# Altium schematic sheet Comparators.SchDoc(Comparators)
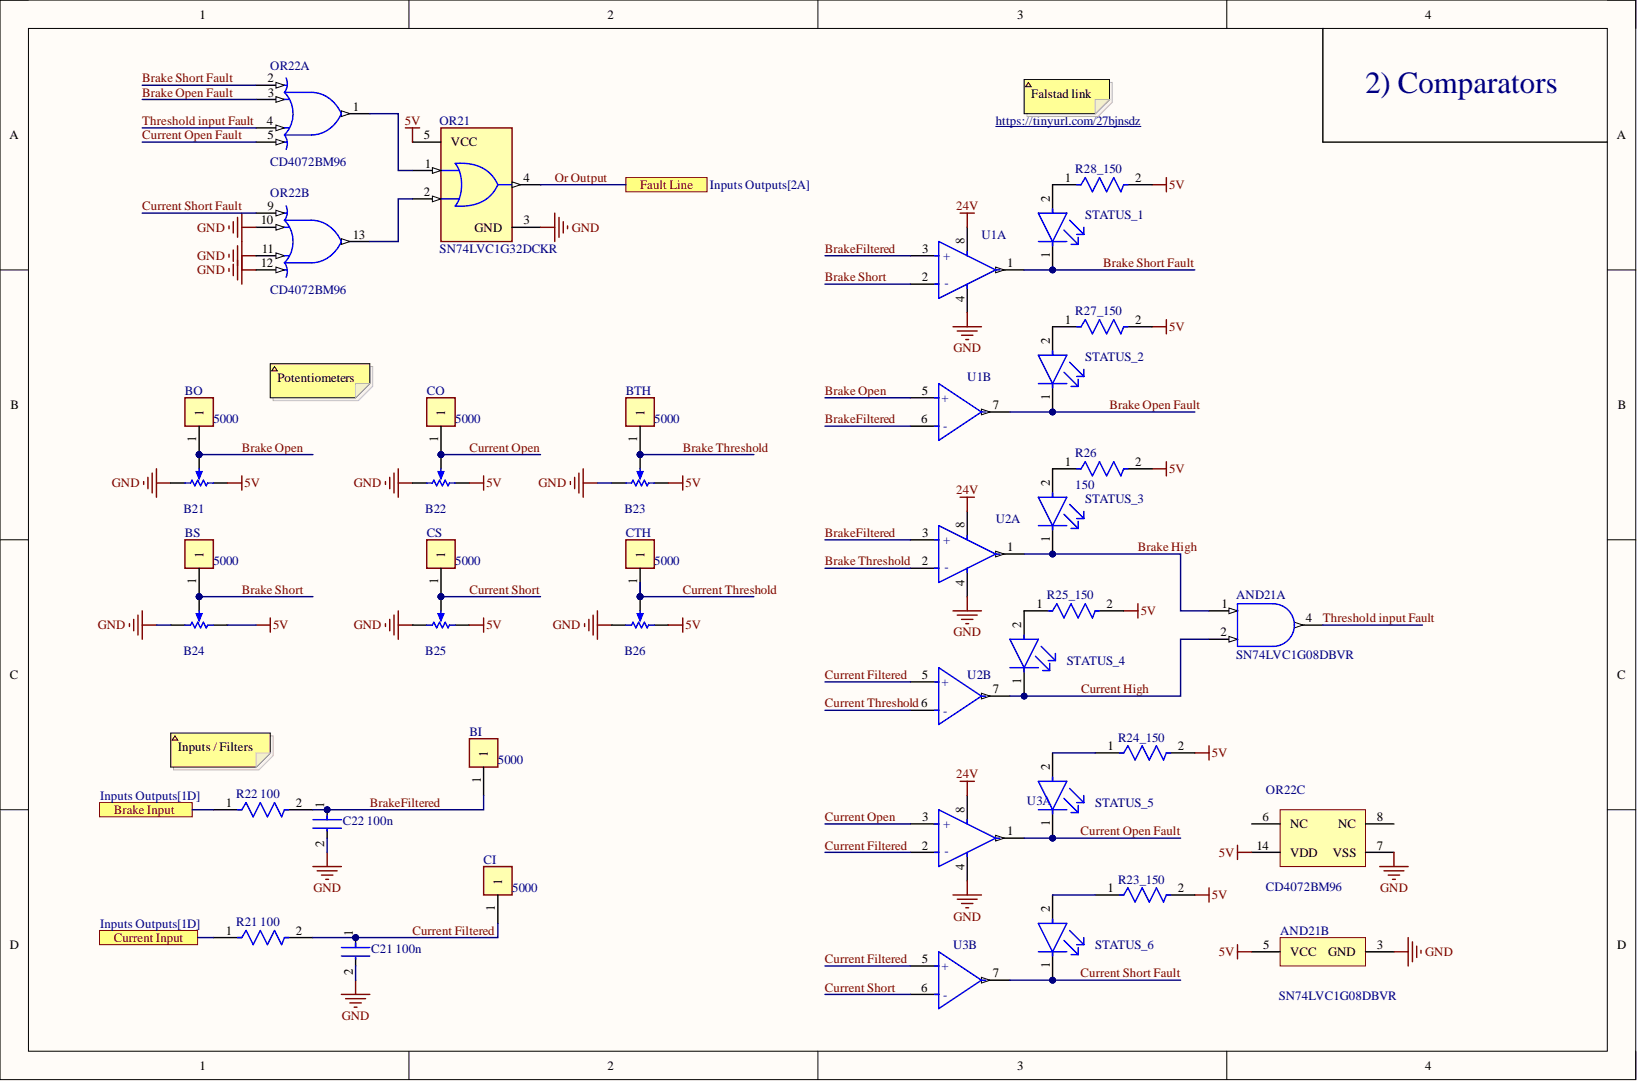
[diagram p0: Comparators.SchDoc]

## Parts
AND21  SN74LVC1G08DBVR  (parts A,B)
B21  3362P-1-103LF  (value not drawn)
B22  3362P-1-103LF  (value not drawn)
B23  3362P-1-103LF  (value not drawn)
B24  3362P-1-103LF  (value not drawn)
B25  3362P-1-103LF  (value not drawn)
B26  3362P-1-103LF  (value not drawn)
BI  5000
BO  5000
BS  5000
BTH  5000
C21_100n  12061C104KAZ2A  (value not drawn)
C22_100n  12061C104KAZ2A  (value not drawn)
CI  5000
CO  5000
CS  5000
CTH  5000
OR21  SN74LVC1G32DCKR
OR22  CD4072BM96  (parts A,B,C)
R21_100  RG2012P-101-B-T5  (value not drawn)
R22_100  RG2012P-101-B-T5  (value not drawn)
R23_150  LTR18EZPF1500  (value not drawn)
R24_150  LTR18EZPF1500  (value not drawn)
R25_150  LTR18EZPF1500  (value not drawn)
R26  150
R27_150  LTR18EZPF1500  (value not drawn)
R28_150  LTR18EZPF1500  (value not drawn)
STATUS_1  LTST-C190KRKT  (value not drawn)
STATUS_2  LTST-C190KRKT  (value not drawn)
STATUS_3  LTST-C190KRKT  (value not drawn)
STATUS_4  LTST-C190KRKT  (value not drawn)
STATUS_5  LTST-C190KRKT  (value not drawn)
STATUS_6  LTST-C190KRKT  (value not drawn)
U1  LM2903DGKR  (value not drawn)  (parts A,B)
U2  LM2903DGKR  (value not drawn)  (parts A,B)
U3  LM2903DGKR  (value not drawn)  (parts A,B)

## Ports
Brake Input, Current Input, Fault Line

## Nets
5V: AND21.5(VCC) B21.1 B22.1 B23.1 B24.1 B25.1 B26.1 OR21.5(VCC) OR22.14(VDD) R23_150.2 R24_150.2 R25_150.2 R26.2 R27_150.2 R28_150.2
24V: U1.8 U2.8 U3.8
GND: AND21.3(GND) B21.3 B22.3 B23.3 B24.3 B25.3 B26.3 C21_100n.2 C22_100n.2 OR21.3(GND) OR22.7(VSS) OR22.10 OR22.11 OR22.12 U1.4 U2.4 U3.4
Brake High: AND21.1 STATUS_3.1 U2.1
Brake Input: R22_100.1 ; port Brake Input
Brake Open: B21.2 BO.1 U1.5(+)
Brake Open Fault: OR22.3 STATUS_2.1 U1.7
Brake Short: B24.2 BS.1 U1.2(-)
Brake Short Fault: OR22.2 STATUS_1.1 U1.1
Brake Threshold: B23.2 BTH.1 U2.2(-)
BrakeFiltered: BI.1 C22_100n.1 R22_100.2 U1.3(+) U1.6(-) U2.3(+)
Current Filtered: C21_100n.1 CI.1 R21_100.2 U2.5(+) U3.2(-) U3.5(+)
Current High: AND21.2 STATUS_4.1 U2.7
Current Input: R21_100.1 ; port Current Input
Current Open: B22.2 CO.1 U3.3(+)
Current Open Fault: OR22.5 STATUS_5.1 U3.1
Current Short: B25.2 CS.1 U3.6(-)
Current Short Fault: OR22.9 STATUS_6.1 U3.7
Current Threshold: B26.2 CTH.1 U2.6(-)
Or Output: OR21.4 ; port Fault Line
Threshold input Fault: AND21.4 OR22.4
NetOR21_1: OR21.1 OR22.1
NetOR21_2: OR21.2 OR22.13
NetR23_150_1: R23_150.1 STATUS_6.2
NetR24_150_1: R24_150.1 STATUS_5.2
NetR25_150_1: R25_150.1 STATUS_4.2
NetR26_1: R26.1 STATUS_3.2
NetR27_150_1: R27_150.1 STATUS_2.2
NetR28_150_1: R28_150.1 STATUS_1.2

Not connected: OR22 6(NC) 8(NC)

## Library data of the parts
AND21 = CMP-0859-00053-3: Single 2-Input Positive-AND Gate, DBV0005A, LARGE T&R; Manufacturer: Texas Instruments; 3-State Output: No; Bits(#): 1; F @ Nom Voltage(Max)(Mhz): 150; Gate Type: AND; ICC @ Nom Voltage(Max)(mA): 0.01; Input Type: CMOS/TTL; Logic: True; Output Drive (IOL/IOH)(Max)(mA): 32/-32; Output Type: CMOS; Rating: Catalog; Technology Family: LVC; tpd @ Nom Voltage(Max)(ns): 4.5,6,4,5; VCC(Max)(V): 5.5; VCC(Min)(V): 1.65; Voltage(Nom)(V): 1.8,2.5,3.3,5; Component Kind: Standard; DatasheetVersion: SCES217Y; Generic Part Number: SN74LVC1G08; Library Name: Altium Content Vault; Operating Temperature Range(C): -40 to 125,-40 to 85; PackageReference: DBV0005A; Schmitt Trigger: No; Sub-Family: AND Gate
B21-B26 = CMP-1747-00004-1: Square Trimpot(R) Trimming Potentiometer, 10 KOhm, 300 V, -55 to 125 degC, 3-Pin THD, RoHS, Tube; Power Rating: 1 W; Resistance: 50 mohm; Tolerance: 1%; Voltage Rating: 220 mV; Case Code (Imperial): 0612; Case Code (Metric): 1632; Case/Package: 1206; Component Kind: Standard; ComponentLink1Description: Manufacturer URL; ComponentLink1URL: http://www.bourns.com/; ComponentLink2Description: Datasheet; ComponentLink2URL: http://www.bourns.com/docs/Product-Datasheets/3362.pdf; DatasheetVersion: May-16; Height: 0.6 mm; Length: 3.2 mm; Library Name: Altium Content Vault; Max Operating Temperature: 125degC; Min Operating Temperature: -25degC; Mount: Surface Mount; Package Quantity: 5000; PackageDescription: 3-Pin Through Hole Device, Body 6.6 x 6.99 mm, Pitch 2.54 mm; PackageReference: 3326P; Packaging: Tape and Reel; Pins: 2; REACH SVHC: No SVHC; RoHSCompliant: True; Width: 1.6 mm
BI BO BS BTH CI CO CS CTH = CMP-19636-000027-1: PC TEST POINT MINIATURE RED; Body Material: Bronze; Hole Diameter: 1.6mm; Inside Diameter: 1.27mm; Insulation: Insulated; Number of Contacts: 1; Outside Diameter: 2.54mm; Plating: Silver; Color: Red; Component Kind: Standard; Contact Material: Bronze; Contact Plating: Tin; Flammability Rating: UL94 V-0; Height: 0.3inch; Housing Color: Red; Lead Free: Lead Free; Length: 7.62mm; Library Name: Altium Content Vault; Material: Nylon; Mount: PCB; Number of Ports: 1; Orientation: Straight; Package Quantity: 100; Packaging: Bulk; REACH SVHC: No SVHC; RoHS Compliant: Yes; Series: THM
C21_100n C22_100n = CMP-04427-004798-1: General Purpose Ceramic Capacitor, 1206, 100nF, 10%, X7R, 15%, 100V; Capacitance: 100nF; Case Code (Imperial): 1206; Case Code (Metric): 3216; Case/Package: 1206; Component Kind: Standard; Construction: Flat; Depth: 1.6mm; Dielectric: X7R; Features: Soft Termination; Lead Free: Lead Free; Length: 3.2mm; Library Name: Altium Content Vault; Max Operating Temperature: 125°C; Min Operating Temperature: -55°C; Mount: Surface Mount; Number of Pins: 2; Package Quantity: 1; Packaging: Tape and Reel; Radiation Hardening: No; REACH SVHC: No SVHC; RoHS Compliant: Yes; Series: FLEXITERM®; Termination: SMD/SMT; Thickness: 0.05inch; Tolerance: 10%; Voltage Rating: 100V; Voltage Rating (DC): 100V; Width: 0.063inch
OR21 = CMP-0859-00376-4: IC GATE OR 1CH 2-INP SC70-5; High Level Output Current: -32mA; Low Level Output Current: 32mA; Max Supply Voltage: 5.5V; Min Supply Voltage: 1.65V; Number of Bits: 1; Number of Input Lines: 2; Propagation Delay: 4ns; Quiescent Current: 10uA; Schmitt Trigger Input: No; Turn-On Delay Time: 4.5ns; Case/Package: SC; Component Kind: Standard; Contact Plating: Gold; Height: 0.9mm; Lead Free: Lead Free; Length: 2mm; Library Name: Altium Content Vault; Logic Function: OR; Max Operating Temperature: 125°C; Max Output Current: 32mA; Min Operating Temperature: -40°C; Mount: Surface Mount; Number of Circuits: 1; Number of Elements: 1; Number of Gates: 1; Number of Inputs: 2; Number of Output Lines: 1; Number of Outputs: 1; Number of Pins: 5; Output Current: 32mA; Package Quantity: 1; Packaging: Tape and Reel; Radiation Hardening: No; REACH SVHC: No SVHC; Termination: SMD/SMT; Weight: 8.8E-05oz; Width: 1.25mm
OR22 = CMP-1573-00053-3: CMOS Dual 4-Input OR Gate, D0014A, LARGE T&R; Manufacturer: Texas Instruments; Bits(#): 2; F @ Nom Voltage(Max)(Mhz): 8; ICC @ Nom Voltage(Max)(mA): 0.015; Output Drive (IOL/IOH)(Max)(mA): 1.5/-1.5; Rating: Catalog; tpd @ Nom Voltage(Max)(ns): 120; VCC(Max)(V): 18; VCC(Min)(V): 3; Voltage(Nom)(V): 10; Code_JEDEC: MS-012; Component Kind: Standard; DatasheetVersion: SCHS056D; Generic Part Number: CD4072B; Input Type: CMOS; Library Name: Altium Content Vault; Operating Temperature Range(C): -55 to 125; Output Type: CMOS; PackageReference: D0014A; PackageVersion: http://www.ti.com/lit/ml/mpds177g/mpds177g.pdf
R21_100 R22_100 = CMP-2001-02694-2: Automotive Metal Thin Film Chip Resistor, 0805, 100Ω, 0.1%, 25ppm/°C, 0.125W, 150V; Max Power Dissipation: 125mW; Power Rating: 100mW; Resistance: 100R; Voltage Rating: 100V; Case Code (Imperial): 0805; Case Code (Metric): 2012; Case/Package: 0805; Component Kind: Standard; Features: Automotive AEC-Q200; Height: 0.4mm; Lead Free: Lead Free; Length: 2mm; Library Name: Altium Content Vault; Max Operating Temperature: 155°C; Min Operating Temperature: -55°C; Number of Terminations: 2; Package Quantity: 5000; Packaging: Tape and Reel; Resistor Type: Metal Film; Series: RG; Size Code: 0805; Temperature Coefficient: 25ppm/°C; Termination: SMD/SMT; Tolerance: 0.1%; Voltage Rating (DC): 150V; Width: 1.25mm
R23_150 R24_150 R25_150 R26 R27_150 R28_150 = CMP-08839-001220-1: High power chip resistors wide terminal type 150Ω 1% 0.75W 0612; Max Power Dissipation: 750mW; Resistance: 150R; Temperature Coefficient: 100ppm/°C; Case Code (Imperial): 1206; Case Code (Metric): 3216; Case/Package: 1206; Component Kind: Standard; Features: Automotive AEC-Q200; Height: 0.026inch; Length: 0.063inch; Library Name: Altium Content Vault; Max Operating Temperature: 155°C; Min Operating Temperature: -55°C; Number of Terminations: 2; Package Quantity: 1; Packaging: Cut Tape; Resistor Type: Thick Film; Series: LTR; Tolerance: 1%; Width: 0.126inch
STATUS_1-STATUS_6 = CMP-1674-00011-2: LED RED CLEAR CHIP SMD 2V 20Ma; Dominant Wavelength: 631nm; Forward Current: 30mA; Forward Voltage: 2.4V; Lens Color: Colorless; Lens Style: Diffused; Luminous Intensity: 54mcd; Number of Elements: 1; Power Dissipation: 75mW; Reverse Voltage: 5V; Viewing Angle: 130°; Wavelength: 639nm; Case Code (Imperial): 0603; Case Code (Metric): 1608; Case/Package: 0603; Color: Red; Component Kind: Standard; Depth: 0.8mm; Height: 0.8mm; Illumination Color: Red; Lead Free: Lead Free; Length: 1.6mm; Lens Transparency: Clear; Library Name: Altium Content Vault; Max Operating Temperature: 85°C; Min Operating Temperature: -55°C; Mount: Surface Mount; Number of LEDs: 1; Number of Pins: 2; Package Quantity: 1; Packaging: Tape and Reel; Power Rating: 75mW; Radiation Hardening: No; Test Current: 20mA; Width: 0.8mm
U1-U3 = CMP-04896-000015-1: IC DUAL DIFF COMPARATOR 8VSSOP; Manufacturer: Texas Instruments; Input Bias Current: -0.025uA; Input Offset Voltage: 2mV; Max Junction Temp: +150°C; Max Supply Voltage: 30V; Min Supply Voltage: 2V; Nominal Supply Current: 0.8mA; Number Of Channels: 2; Response Time: 0.3-1.3us; Standoff Height: 0.05mm; Voltage Gain: 100V/mV; Ambient Temperature Range High: +125°C; Ambient Temperature Range Low: -40°C; Automotive: No; Category: IC; Component Kind: Standard; ComponentLink2Description: Datasheet; ComponentLink2URL: http://www.ti.com/lit/ds/symlink/lm2903.pdf; Device Class L1: Integrated Circuits (ICs); Device Class L2: Linear ICs; Device Class L3: Comparators; Digikey Description: IC DUAL DIFF COMPARATOR 8VSSOP; Height: 1.1mm; Lead Free: Yes; Library Name: Altium Content Vault; Manufacturer Part Number: LM2903DGKR; Mouser Description: Analog Comparators Dual Differential Comparator; Octopart: https://octopart.com/lm2903dgkr-texas+instruments-428373; Package: DGK0008A; RoHS: TRUE; Temperature: -40°C to +125°C; Temperature Range High: +125°C; Temperature Range Low: -40°C
